# Altium schematic sheet 无线串口采样模块.SchDoc(无线串口采样模块)
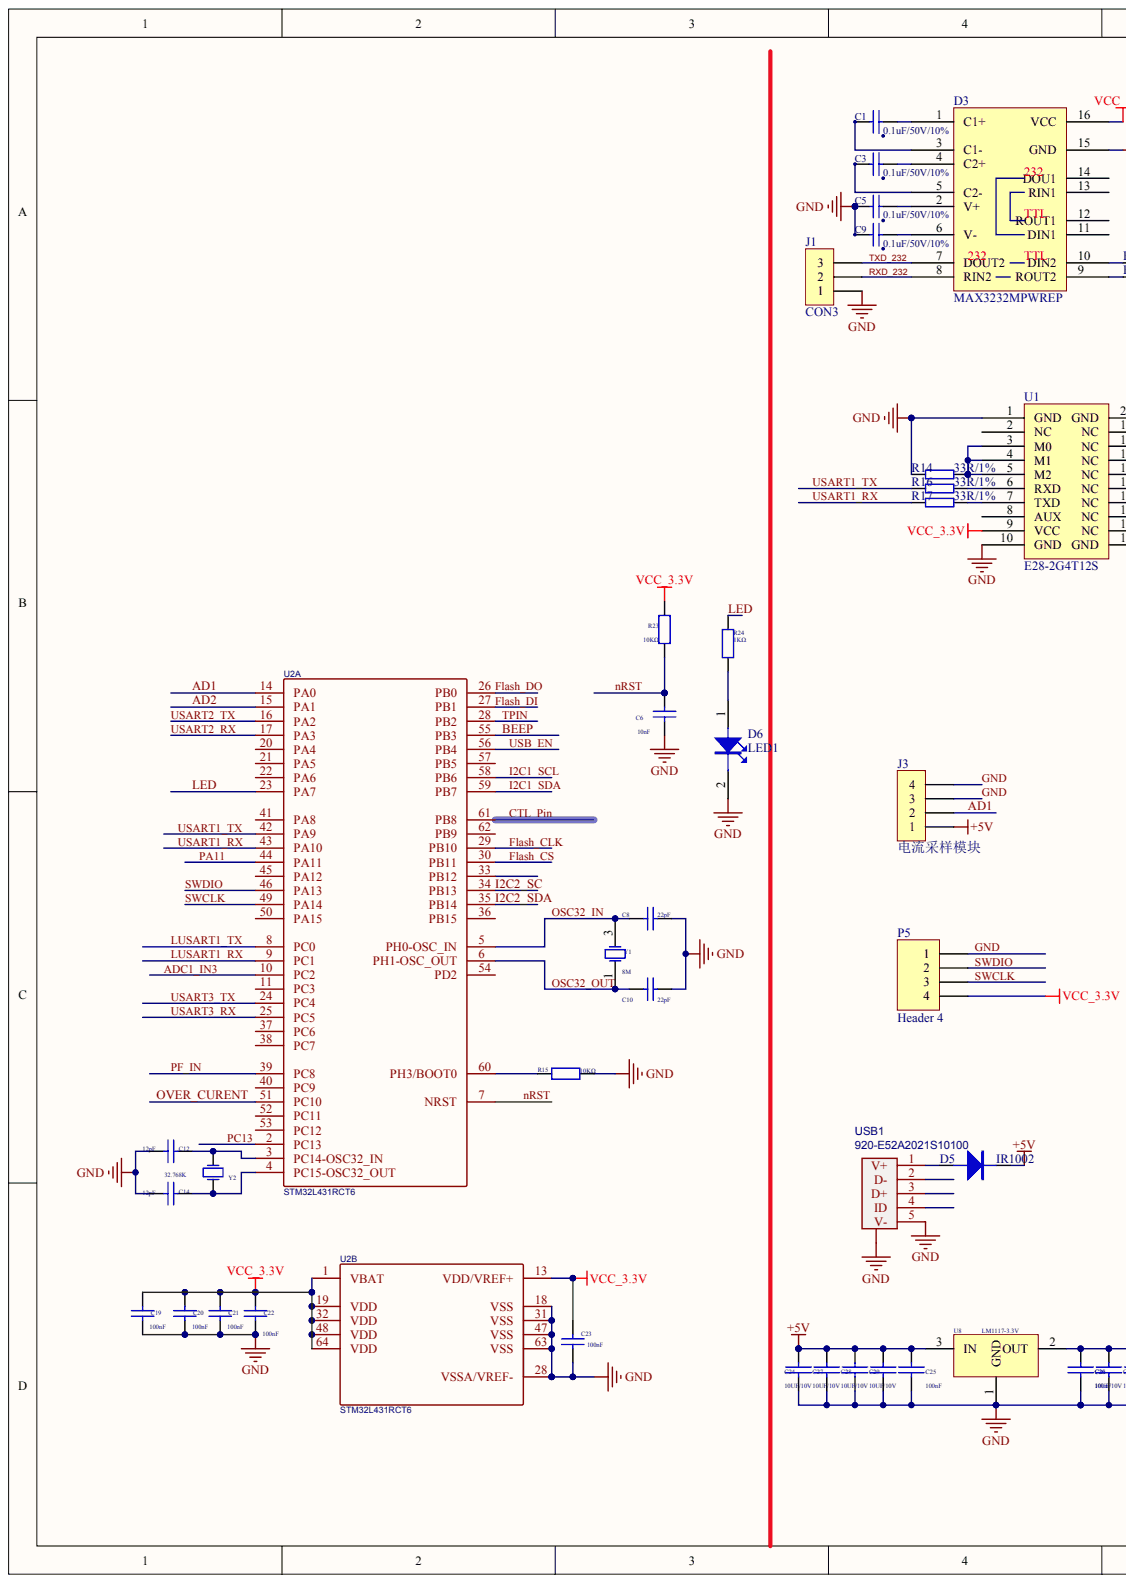
[diagram p0: 无线串口采样模块.SchDoc, left]
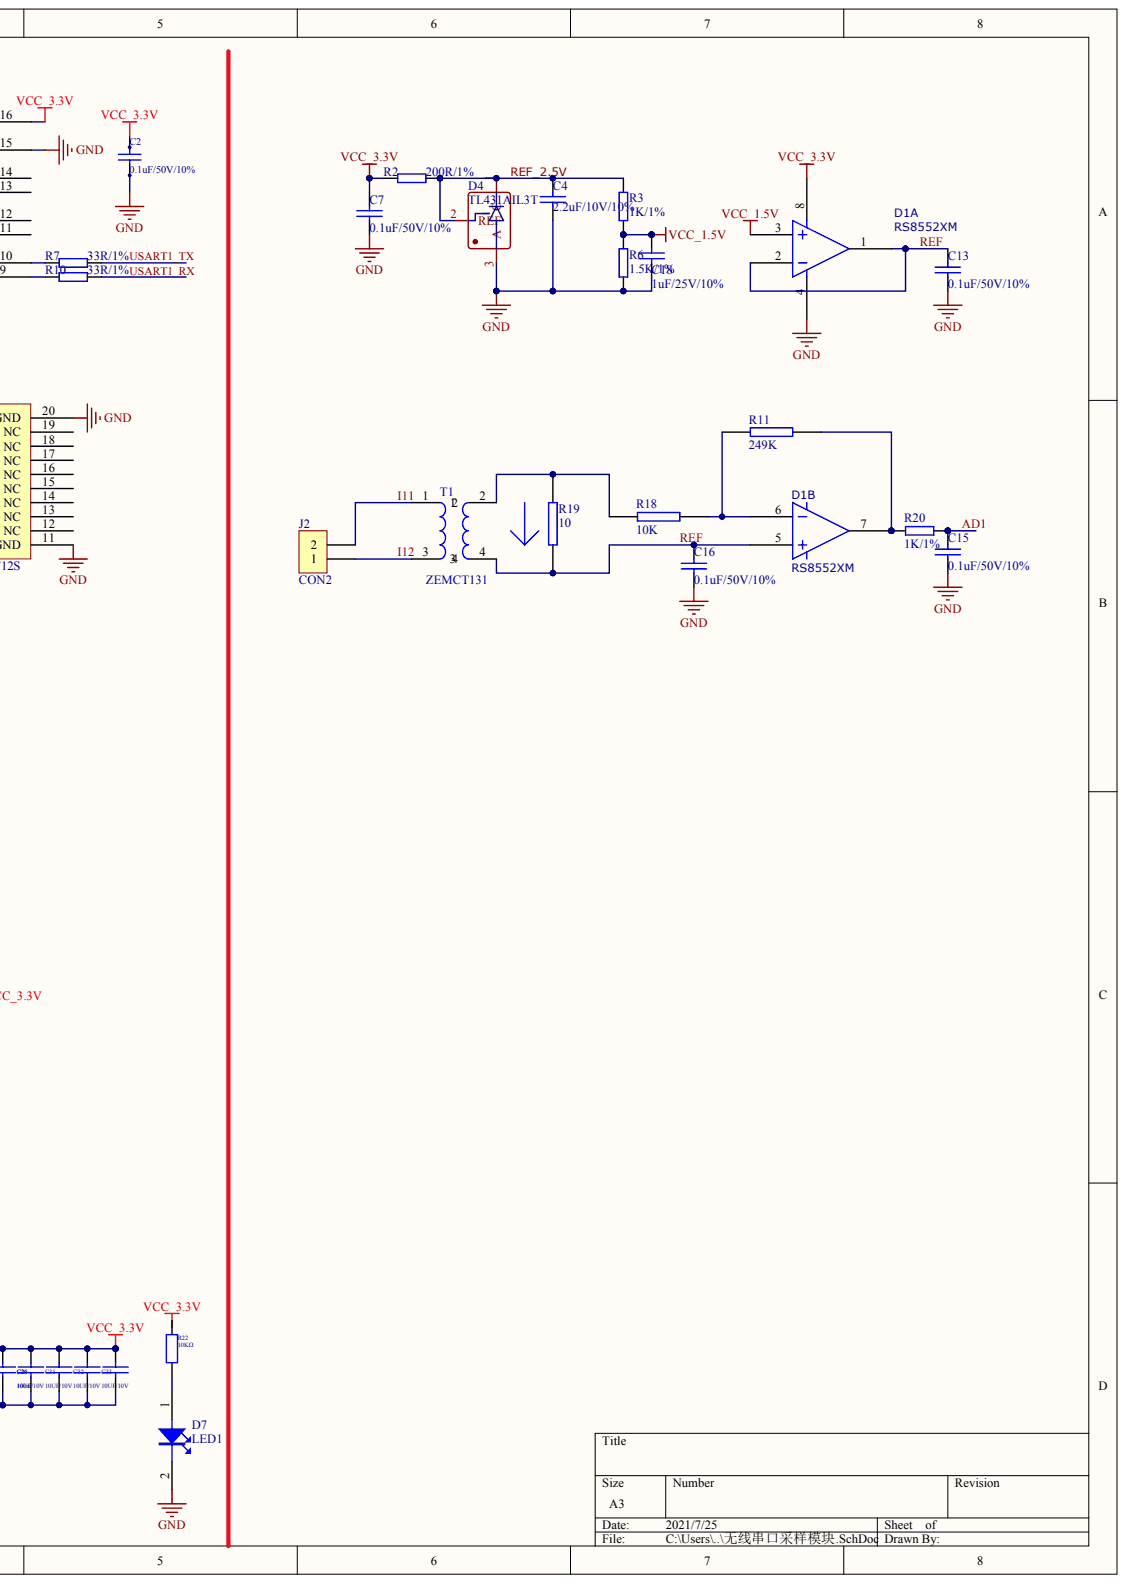
[diagram p1: 无线串口采样模块.SchDoc, right]

## Parts
C1  0.1uF/50V/10%
C2  0.1uF/50V/10%
C3  0.1uF/50V/10%
C4  2.2uF/10V/10%
C5  0.1uF/50V/10%
C6  10nF
C7  0.1uF/50V/10%
C8  22pF
C9  0.1uF/50V/10%
C10  22pF
C12  12pF
C13  0.1uF/50V/10%
C14  12pF
C15  0.1uF/50V/10%
C16  0.1uF/50V/10%
C18  1uF/25V/10%
C19  100nF
C20  100nF
C21  100nF
C22  100nF
C23  100nF
C24  10UF/10V
C25  100nF
C26  100nF
C27  10UF/10V
C28  10UF/10V
C29  10UF/10V
C30  10UF/10V
C31  10UF/10V
C32  10UF/10V
C33  10UF/10V
D1  RS8552XM  (parts A,B)
D3  MAX3232MPWREP
D4  TL431AIL3T
D5  IR1002
D6  LED1
D7  LED1
J1  CON3
J2  CON2
J3  电流采样模块
P5  Header 4
R2  200R/1%
R3  1K/1%
R6  1.5K/1%
R7  33R/1%
R10  33R/1%
R11  249K
R14  33R/1%
R15  10KΩ
R16  33R/1%
R17  33R/1%
R18  10K
R19  10
R20  1K/1%
R22  10KΩ
R23  10KΩ
R24  1KΩ
T1  ZEMCT131
U1  E28-2G4T12S
U2  STM32L431RCT6  (parts A,B)
U8  LM1117-3.3V
USB1  920-E52A2021S10100
Y1  8M
Y2  32.768

## Nets
+5V: C24.1 C25.1 C27.1 C28.1 C29.1 D5.2 J3.1 U8.3
VCC_1.5V: C18.2 D1.3 R3.2 R6.1
VCC_3.3V: C2.2 C7.2 C19.2 C20.2 C21.2 C22.2 C23.2 C26.1 C30.1 C31.1 C32.1 C33.1 D1.8 D3.16(VCC) P5.4 R2.1 R22.2 R23.2 U1.9(VCC) U2.1(VBAT) U2.13(VDD/VREF+) U2.19(VDD) U2.32(VDD) U2.48(VDD) U2.64(VDD) U8.2
AD1: C15.2 J3.2 R20.2 U2.14(PA0)
AD2: U2.15(PA1)
ADC1_IN3: U2.10(PC2)
BEEP: U2.55(PB3)
CTL_PIN: U2.61(PB8)
FLASH_CLK: U2.29(PB10)
FLASH_CS: U2.30(PB11)
FLASH_DI: U2.27(PB1)
FLASH_DO: U2.26(PB0)
GND: C2.1 C4.1 C5.2 C6.1 C7.1 C8.2 C9.2 C10.2 C12.2 C13.1 C14.2 C15.1 C16.1 C18.1 C19.1 C20.1 C21.1 C22.1 C23.1 C24.2 C25.2 C26.2 C27.2 C28.2 C29.2 C30.2 C31.2 C32.2 C33.2 D1.4 D3.15(GND) D4.3 D6.2 D7.2 J1.1 J3.3 J3.4 P5.1 R6.2 R14.1 R15.2 U1.1(GND) U1.10(GND) U1.11(GND) U1.20(GND) U2.18(VSS) U2.28 U2.31(VSS) U2.47(VSS) U2.63(VSS) U8.1 USB1.0(V-) USB1.5
I2C1_SCL: U2.58(PB6)
I2C1_SDA: U2.59(PB7)
I2C2_SC: U2.34(PB13)
I2C2_SDA: U2.35(PB14)
I11: J2.2 T1.1
I12: J2.1 T1.3
LED: R24.2 U2.23(PA7)
LUSART1_RX: U2.9(PC1)
LUSART1_TX: U2.8(PC0)
NRST: C6.2 R23.1 U2.7(NRST)
OSC32_IN: C8.1 U2.5(PH0-OSC_IN) Y1.3
OSC32_OUT: C10.1 U2.6(PH1-OSC_OUT) Y1.1
OVER_CURENT: U2.51(PC10)
PA11: U2.44(PA11)
PC13: U2.2(PC13)
PF_IN: U2.39(PC8)
REF: C13.2 C16.2 D1.1 D1.2 D1.5 R19.1 T1.4
REF_2.5V: C4.2 D4.1 D4.2 R2.2 R3.1
RXD_232: D3.8(RIN2) J1.2
SWCLK: P5.3 U2.49(PA14)
SWDIO: P5.2 U2.46(PA13)
TPIN: U2.28
TXD_232: D3.7(DOUT2) J1.3
USART1_RX: R10.2 R17.1 U2.43(PA10)
USART1_TX: R7.2 R16.1 U2.42(PA9)
USART2_RX: U2.17(PA3)
USART2_TX: U2.16(PA2)
USART3_RX: U2.25(PC5)
USART3_TX: U2.24(PC4)
USB_EN: U2.56(PB4)
NetC1_1: C1.1 D3.1(C1+)
NetC1_2: C1.2 D3.3(C1-)
NetC3_1: C3.1 D3.4(C2+)
NetC3_2: C3.2 D3.5(C2-)
NetC5_1: C5.1 D3.2(V+)
NetC9_1: C9.1 D3.6(V-)
NetC12_1: C12.1 U2.3(PC14-OSC32_IN) Y2.2
NetC14_1: C14.1 U2.4(PC15-OSC32_OUT) Y2.1
NetD1_6: D1.6 R11.1 R18.1
NetD1_7: D1.7 R11.2 R20.1
NetD3_9: D3.9(ROUT2) R10.1
NetD3_10: D3.10(DIN2) R7.1
NetD5_1: D5.1 USB1.1(V+)
NetD6_1: D6.1 R24.1
NetD7_1: D7.1 R22.1
NetR14_2: R14.2 U1.3(M0) U1.4(M1) U1.5(M2)
NetR15_1: R15.1 U2.60(PH3/BOOT0)
NetR16_2: R16.2 U1.6(RXD)
NetR17_2: R17.2 U1.7(TXD)
NetR18_2: R18.2 R19.2 T1.2
N00075:

Not connected: D3 11(DIN1) 12(ROUT1) 13(RIN1) 14(DOU1); U1 2(NC) 8(AUX) 12(NC) 13(NC) 14(NC) 15(NC) 16(NC) 17(NC) 18(NC) 19(NC); U2 11(PC3) 20(PA4) 21(PA5) 22(PA6) 33(PB12) 36(PB15) 37(PC6) 38(PC7) 40(PC9) 41(PA8) 45(PA12) 50(PA15) 52(PC11) 53(PC12) 54(PD2) 57(PB5) 62(PB9); USB1 2(D-) 3(D+) 4(ID)

## Library data of the parts
C6 C8 C10 C12 C14 C19-C23 = (no symbol name): 名称: 电容; 封装: 0805; 型号: 1μF
D1 = (no symbol name): SAP: 32111010003
R15 R22 R23 = (no symbol name): 型号: 1KΩ; 名称: 电阻
R24 = (no symbol name): 名称: 电阻; 型号: 1KΩ
U2 = (no symbol name): BOM_Manufacturer: STMicroelectronics; subpart_no: 1; SMT Type: Extend; BOM_Supplier: LCSC; BOM_Manufacturer Part: STM32L431RCT6; BOM_Supplier Part: C92468; BOM_LCSC Assembly: Yes; spicePre: U
USB1 = (no symbol name): BOM_Manufacturer: ValuePro; BOM_Manufacturer Part: micro USBFemale; BOM_Supplier: LCSC; BOM_Supplier Part: C10418; BOM_JLCPCB Part Class: Extended Part; spicePre: U; spiceSymbolName: micro USBFemale
Y2 = (no symbol name): Comment_1: 25MHz ±20ppm 20pF; Published: 8-Jun-2000; LatestRevisionDate: 17-Jul-2002; LatestRevisionNote: Re-released for DXP Platform.; PackageReference: R38; Publisher: Altium Limited
C1-C5 C7 C9 C13 C15 C16 C18 C24-C33 D3-D7 J1-J3 P5 R2 R3 R6 R7 R10 R11 R14 R16-R20 T1 U1 U8 Y1 = (no symbol name)
  C1-C3 C5 C9: PackageReference: RAD-0.3; LatestRevisionNote: Re-released for DXP Platform.; LatestRevisionDate: 17-Jul-2002; Published: 8-Jun-2000
  P5: LatestRevisionDate: 17-Jul-2002; LatestRevisionNote: Re-released for DXP Platform.
  Y1: Published: 8-Jun-2000; LatestRevisionDate: 17-Jul-2002; LatestRevisionNote: Re-released for DXP Platform.; PackageReference: R38
  C1-C3 C5 C9 P5 Y1: Publisher: Altium Limited
